# Altium schematic sheet NEO9M.SchDoc(NEO9M)
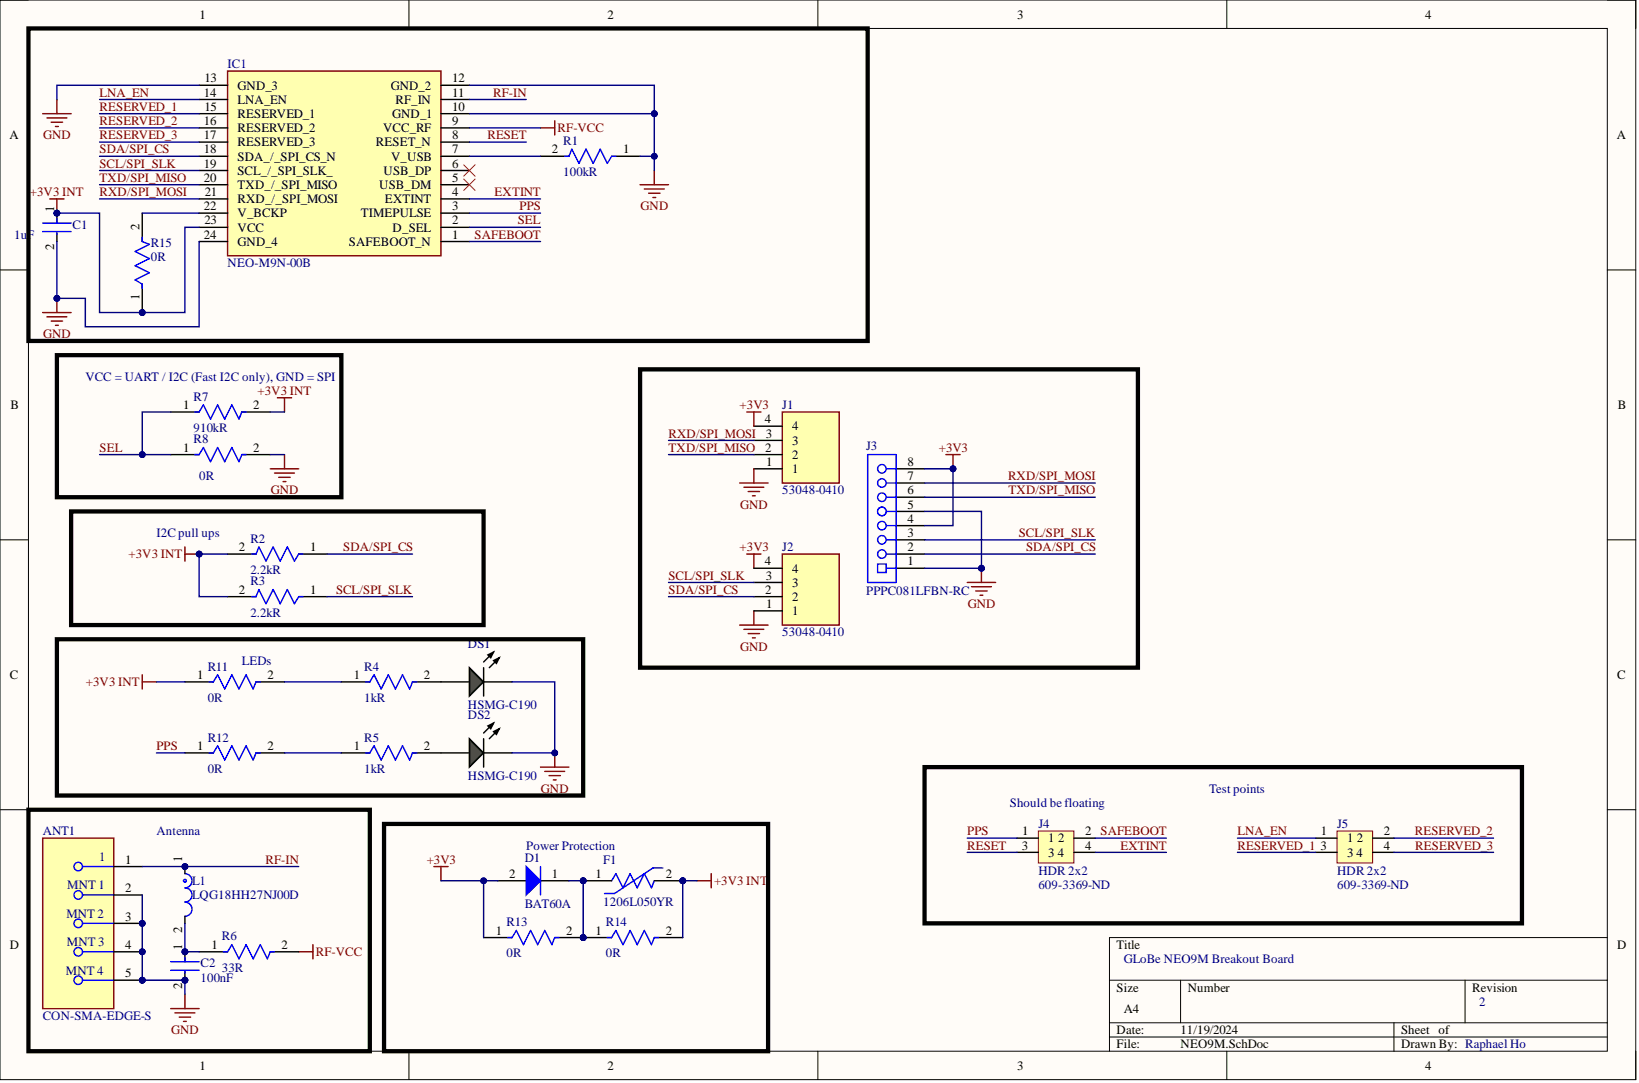
[diagram p0: NEO9M.SchDoc]

## Parts
ANT1  CON-SMA-EDGE-S
C1  1uF
C2  100nF
D1  BAT60A
DS1  HSMG-C190
DS2  HSMG-C190
F1  1206L050YR
IC1  NEO-M9N-00B
J1  53048-0410
J2  53048-0410
J3  PPPC081LFBN-RC
J4  HDR 2x2  Supplier Part Number 1: 609-3369-ND
J5  HDR 2x2  Supplier Part Number 1: 609-3369-ND
L1  LQG18HH27NJ00D
R1  100kR
R2  2.2kR
R3  2.2kR
R4  1kR
R5  1kR
R6  33R
R7  910kR
R8  0R
R11  0R
R12  0R
R13  0R
R14  0R
R15  0R

## Nets
+3V3: D1.2 J1.4 J2.4 J3.4 J3.8 R13.1
+3V3 INT: C1.1 F1.2 IC1.23(VCC) R2.2 R3.2 R7.2 R11.1 R14.2 R15.1
GND: ANT1.2 ANT1.3 ANT1.4 ANT1.5 C1.2 C2.2 DS1.2 DS2.2 IC1.10(GND_1) IC1.12(GND_2) IC1.13(GND_3) IC1.24(GND_4) J1.1 J2.1 J3.1 J3.5 R1.1 R8.2
RF-VCC: IC1.9(VCC_RF) R6.2
EXTINT: IC1.4(EXTINT) J4.4
LNA_EN: IC1.14(LNA_EN) J5.1
PPS: IC1.3(TIMEPULSE) J4.1 R12.1
RESERVED_1: IC1.15(RESERVED_1) J5.3
RESERVED_2: IC1.16(RESERVED_2) J5.2
RESERVED_3: IC1.17(RESERVED_3) J5.4
RESET: IC1.8(RESET_N) J4.3
RF-IN: ANT1.1 IC1.11(RF_IN) L1.1
RXD/SPI_MOSI: IC1.21(RXD_/_SPI_MOSI) J1.3 J3.7
SAFEBOOT: IC1.1(SAFEBOOT_N) J4.2
SCL/SPI_SLK: IC1.19(SCL_/_SPI_SLK_) J2.3 J3.3 R3.1
SDA/SPI_CS: IC1.18(SDA_/_SPI_CS_N) J2.2 J3.2 R2.1
SEL: IC1.2(D_SEL) R7.1 R8.1
TXD/SPI_MISO: IC1.20(TXD_/_SPI_MISO) J1.2 J3.6
NetC2_1: C2.1 L1.2 R6.1
NetD1_1: D1.1 F1.1 R13.2 R14.1
NetDS1_1: DS1.1 R4.2
NetDS2_1: DS2.1 R5.2
NetIC1_7: IC1.7(V_USB) R1.2
NetIC1_22: IC1.22(V_BCKP) R15.2
NetR4_1: R4.1 R11.2
NetR5_1: R5.1 R12.2

Not connected: IC1 5(USB_DM) 6(USB_DP)

## Library data of the parts
ANT1 = CMP-204357-000001-1: CONN SMA JACK STR EDGE MNT; Manufacturer: RF Solutions Ltd.; Conn Orientation: Right Angle; Frequency: 18GHz; Impedance: 50Ω; Is Female: yes; Number Of Contacts: 1; Shielding: true; Automotive: No; Category: Conn; Component Kind: Standard; ComponentLink2Description: Datasheet; ComponentLink2URL: https://www.rfsolutions.co.uk/downloads/1498648656DS-CON-EDGE-SMA-4.pdf; Contact Material: Gold; Device Class L1: Connectors; Device Class L2: RF / Coaxial Connectors; Device Class L3: unset; Digikey Description: CONN SMA JACK STR EDGE MNT; Footprint Url: https://forum.upverter.com/uploads/default/original/3X/b/e/be75b907af57498775929d6d9099689c472e0307.pdf; Height: 6.35mm; Lead Free: Yes; Library Name: Altium Content Vault; Manufacturer Part Number: CON-SMA-EDGE-S; Octopart: https://octopart.com/con-sma-edge-s-rf+solutions-70967450; Package: CONN_JACK; RoHS: TRUE
C1 = CMP-2006-02357-2: Ceramic Capacitor for Automotive 1uF  ±10% 16VDC X7R 0603 Paper T/R; Voltage: 16V; Capacitance: 1uF; Case Code (Imperial): 0603; Case Code (Metric): 1608; Case/Package: 0603; Component Kind: Standard; Depth: 0.8mm; Dielectric: X7R; Height: 0.8mm; Lead Free: Lead Free; Length: 1.6mm; Library Name: Altium Content Vault; Max Operating Temperature: 125°C; Min Operating Temperature: -55°C; Mount: Surface Mount; Package Quantity: 1; Packaging: Tape and Reel; Radiation Hardening: No; Ratings: AEC-Q200; REACH SVHC: No SVHC; Termination: SMD/SMT; Thickness: 0.035inch; Tolerance: 10%; Voltage Rating: 16V; Voltage Rating (DC): 16V; Width: 0.031inch
C2 = CMP-2006-02376-2: CAP CER 0.1UF 50V X7R 0603; Tolerance: 10%; Capacitance: 100nF; Case Code (Imperial): 0603; Case Code (Metric): 1608; Case/Package: 0603; Component Kind: Standard; Construction: Flat; Depth: 0.8mm; Dielectric: X7R; Height: 0.8mm; Lead Free: Lead Free; Length: 1.6mm; Library Name: Altium Content Vault; Max Operating Temperature: 125°C; Min Operating Temperature: -55°C; Mount: Surface Mount; Package Quantity: 1; Packaging: Tape and Reel; Radiation Hardening: No; Ratings: AEC-Q200; RoHS Compliant: Yes; Series: GCM; Termination: SMD/SMT; Thickness: 0.035inch; Voltage Rating: 50V; Voltage Rating (DC): 50V; Width: 0.031inch
D1 = CMP-1183-00008-2: Silicon Schottky Diode, -55 to 85 degC, SOD323, Reel, Green; Manufacturer: Infineon; Configuration: Single; CT (max): 35Â pF; Green: TRUE; IF (max): 3,000Â mA; VF (max): 370Â mV; VR (max): 10Â V; Component Kind: Standard; ComponentLink1Description: Manufacturer URL; ComponentLink1URL: http://www.infineon.com/; ComponentLink2Description: Datasheet; ComponentLink2URL: http://www.infineon.com/dgdl/bat60aseries.pdf?folderId=db3a304313d846880113def5812204a1&fileId=db3a304313d846880113def70c9304a9; ComponentLink3Description: Package Specification; ComponentLink3URL: http://www.infineon.com/dgdl/SOT323-Package_Overview.pdf?folderId=db3a30431936bc4b0119533e1dcb3c92&fileId=db3a30431936bc4b011953495f283cb1; Library Name: Altium Content Vault; Mounting Technology: Surface Mount; PackageDescription: 3-Pin Small Outline Transistor Package, 1.25 x 2 mm Body, 0.65 mm Pitch; PackageReference: SOT323; Packing: Reel; PartNumber: BAT60A
DS1 DS2 = CMP-1744-00002-1: Chip LED, Green, 2.2 V, -40 to 85 degC, 2-Pin SMD, RoHS, Tape and Reel; Access Time: 3500 ns; Case\Package: SOIC; Clock Frequency: 100 KHz; Density: 32 kb; Frequency: 400 KHz; Interface: Serial; Max Supply Voltage: 5.5 V; Min Supply Voltage: 1.7 V; Nominal Supply Current: 2 mA; Component Kind: Standard; ComponentLink1Description: Manufacturer URL; ComponentLink1URL: http://www.avagotech.com/; ComponentLink2Description: Datasheet; ComponentLink2URL: http://docs.avagotech.com/docs/AV02-0551EN; ComponentLink3Description: Package Specification; ComponentLink3URL: http://www.fairchildsemi.com/dwg/MA/MA03D.pdf; DatasheetVersion: Mar-12; Height: 1.5 mm; Length: 5 mm; Library Name: Altium Content Vault; Max Clock Frequency: 100 KHz; Max Operating Temperature: 85 degC; Min Operating Temperature: -40 degC; Mount: Surface Mount; Package Quantity: 3000; PackageDescription: 2-Pin Surface Mount Device, Body 1.6 x 0.8 mm, Height 0.9 mm; PackageReference: HSMX-C190; Packaging: Tape and Reel; Pins: 8; Radiation Hardening: No; REACH SVHC: No SVHC; RoHSCompliant: true; Width: 4 mm
F1 = CMP-08606-000073-1: PTC RESET FUSE 6V 500MA 1206; Approvals: cULus; Current Rating: 100A; Max Power Dissipation: 600mW; Resistance: 700mR; Time to Trip: 0.1s; Trip Current: 1A; Case Code (Imperial): 1206; Case Code (Metric): 3216; Case/Package: 1206; Component Kind: Standard; Height: 0.75mm; Hold Current: 500mA; Lead Free: Lead Free; Length: 3.4mm; Library Name: Altium Content Vault; Max Current Rating: 100A; Max Operating Temperature: 85°C; Max Voltage Rating (DC): 6V; Min Operating Temperature: -40°C; Operating Supply Voltage: 6V; Package Quantity: 4000; Packaging: Tape and Reel; Power Dissipation: 600mW; REACH SVHC: No SVHC; RoHS Compliant: Yes; Series: 1206L; Terminal Material: Copper; Termination: SMD/SMT; Voltage Rating: 6V; Voltage Rating (DC): 6V; Width: 1.8mm; Working Voltage: 6V
IC1 = NEO-M9N-00B: Integrated Circuit; Manufacturer_Name: U-Blox; Component Kind: Standard; Datasheet Link: https://content.u-blox.com/sites/default/files/NEO-M9N-00B_DataSheet_UBX-19014285.pdf; Height: 2.6mm; Manufacturer_Part_Number: NEO-M9N-00B; Mouser Part Number: 377-NEO-M9N-00B; Mouser Price/Stock: https://www.mouser.co.uk/ProductDetail/u-blox/NEO-M9N-00B?qs=DPoM0jnrROVeJ6BHpcwcjw%3D%3D
J1 J2 = 53048-0410: Connector; Manufacturer_Name: Molex; Component Kind: Standard; Datasheet Link: https://www.molex.com/pdm_docs/sd/530480410_sd.pdf; Height: 3.7mm; Manufacturer_Part_Number: 53048-0410; Mouser Part Number: 538-53048-0410; Mouser Price/Stock: https://www.mouser.co.uk/ProductDetail/Molex/53048-0410?qs=359VwiUTsp2msj5Tobchuw%3D%3D
J3 = CMP-002-00028-2: Female Header, Pitch 2.54 mm, 1 x 8 Position, Height 8.5 mm, Tail Length 3.2 mm, -40 to 105 degC, RoHS, Bulk; Manufacturer: Sullins; Case/Package: PPPC081LFBN-RC; Component Kind: Standard; Connector Type: Header; Datasheet URL: http://sullinscorp.com/catalogs/101_PAGE114-115_.100_FEMALE_HDR.pdf; Datasheet Version: Jan-15; Gender: Female; Max Operating Temperature: 105°C; Min Operating Temperature: -40°C; Mounting Technology: TH; Package Description: Female Header, Pitch 2.54 mm, 1 x 8 Position, Height 8.5 mm, Tail Length 3.2 mm; Package Reference: PPPC081LFBN-RC; RoHS Compliant: Unknown; Library Name: CubeSat Team
J4 J5 = 68602-204HLF: CONN HEADER VERT 4POS 2.54MM; Manufacturer: Amphenol FCI; Connector Type: Male Pin; Contact Post Length: 3.81mm; Current Rating: 3A; Gender: Male; Insulation Resistance: 5GR; Number of Contacts: 4; Row Spacing: 0.1inch; Voltage Rating: 1.5kV; Category: Headers and Wire Housings; Color: Black; Component Kind: Standard; ComponentLink1Description: https://datasheet.ciiva.com/728/67996-728884.pdf?src-supplier=Digi-Key; ComponentLink1URL: https://datasheet.ciiva.com/728/67996-728884.pdf?src-supplier=Digi-Key; Contact Gender: Male; Contact Material: Bronze; Contact Mating Length: 0.23inch; Contact Plating: Gold,GXT; Flammability Rating: UL94 V-0; Housing Material: Thermoplastic; Library Name: Male_Header_2x2_2.54mm.Schlib; Manufacturer Part Number: 68602-204HLF; Max Operating Temperature: 125°C; Min Operating Temperature: -65°C; Mount: Through Hole; Number of Positions: 4; Number of Rows: 2; Package Quantity: 100; Packaging: Bulk; Pitch: 2.54mm; Pricing 1: 1=0,34000, 10=0,31900, 100=0,22320, 500=0,19132, 1000=0,15943, 5000=0,13665, 10000=0,12754 (USD); Radiation Hardening: No; RoHS: Yes; Stock 1: 3699; Supplier 1: Digi-Key; Termination: Solder
L1 = CMP-06042-012021-1: Multilayer type Inductor for Automotive 27nH ±5% 0.54Ω 500mA 0603; DC Resistance (DCR): 4.18mR; Case/Package: 0603; Component Kind: Standard; Inductance: 27nH; Library Name: Altium Content Vault; Package Quantity: 4000; Packaging: Tape and Reel; Termination: SMD/SMT
R1 = CMP-2000-04857-2: RES 100kΩ 5.00% 0.1W 0603; Max Power Dissipation: 100mW; Temperature Coefficient: 100ppm/°C; Tolerance: 5%; Voltage Rating: 50V; Case Code (Imperial): 0603; Case Code (Metric): 1608; Case/Package: 0603; Component Kind: Standard; Construction: Rectangular; Depth: 0.8mm; Features: Moisture Resistant; Lead Free: Lead Free; Length: 1.6mm; Library Name: Altium Content Vault; Max Operating Temperature: 155°C; Military Standard: Not; Min Operating Temperature: -55°C; Number of Terminations: 2; Packaging: Tape and Reel; Power Rating: 100mW; Ratings: AEC-Q200; Resistance: 100kR; Resistor Type: Thick Film; RoHS Compliant: Yes; Series: AC; Termination: SMD/SMT
R2 R3 = CMP-2000-04866-2: RES 2.2kΩ 5.00% 0.1W 0603; Max Power Dissipation: 100mW; Temperature Coefficient: 100ppm/°C; Tolerance: 5%; Voltage Rating: 50V; Case Code (Imperial): 0603; Case Code (Metric): 1608; Case/Package: 0603; Component Kind: Standard; Contact Plating: Tin; Features: Moisture Resistant; Height: 0.45mm; Lead Free: Lead Free; Length: 1.6mm; Library Name: Altium Content Vault; Max Operating Temperature: 155°C; Min Operating Temperature: -55°C; Number of Terminations: 2; Packaging: Tape and Reel; Power Rating: 100mW; Radiation Hardening: No; Ratings: AEC-Q200; Resistance: 2.2kR; Resistor Type: Thick Film; RoHS Compliant: Yes; Series: AC; Termination: SMD/SMT; Width: 0.8mm
R4 R5 = CMP-03412-041970-1: RES 1kΩ 1.00% 0.1W 0603; Tolerance: 1%; Case/Package: 0603; Component Kind: Standard; Lead Free: Lead Free; Library Name: Altium Content Vault; Resistance: 1kR; RoHS Compliant: Yes
R6 = CMP-03412-046720-1: RES 33Ω 0.50% 0.1W 0603; Component Kind: Standard; Library Name: Altium Content Vault; RoHS Compliant: Yes
R7 = CMP-03412-028406-2: RES 910KΩ 1.00% 0.1W 0603; Max Power Dissipation: 100mW; Temperature Coefficient: 100ppm/°C; Tolerance: 1%; Voltage Rating: 50V; Case Code (Imperial): 0603; Case Code (Metric): 1608; Case/Package: 0603; Component Kind: Standard; Features: Moisture Resistant; Height: 0.022inch; Length: 0.063inch; Library Name: Altium Content Vault; Max Operating Temperature: 155°C; Min Operating Temperature: -55°C; Number of Terminations: 2; Packaging: Tape and Reel; Power Rating: 100mW; Resistance: 910kR; Resistor Type: Thick Film; RoHS Compliant: Yes; Series: AC; Termination: SMD/SMT; Width: 0.8mm
R8 R11-R15 = CMP-03412-047235-1: RES 0Ω 0.00% 0.1W 0603; Resistance: 0mR; Tolerance: 5%; Case/Package: 0603; Component Kind: Standard; Lead Free: Lead Free; Library Name: Altium Content Vault; RoHS Compliant: Yes
